# Altium schematic sheet User_Input.SchDoc("User Input")
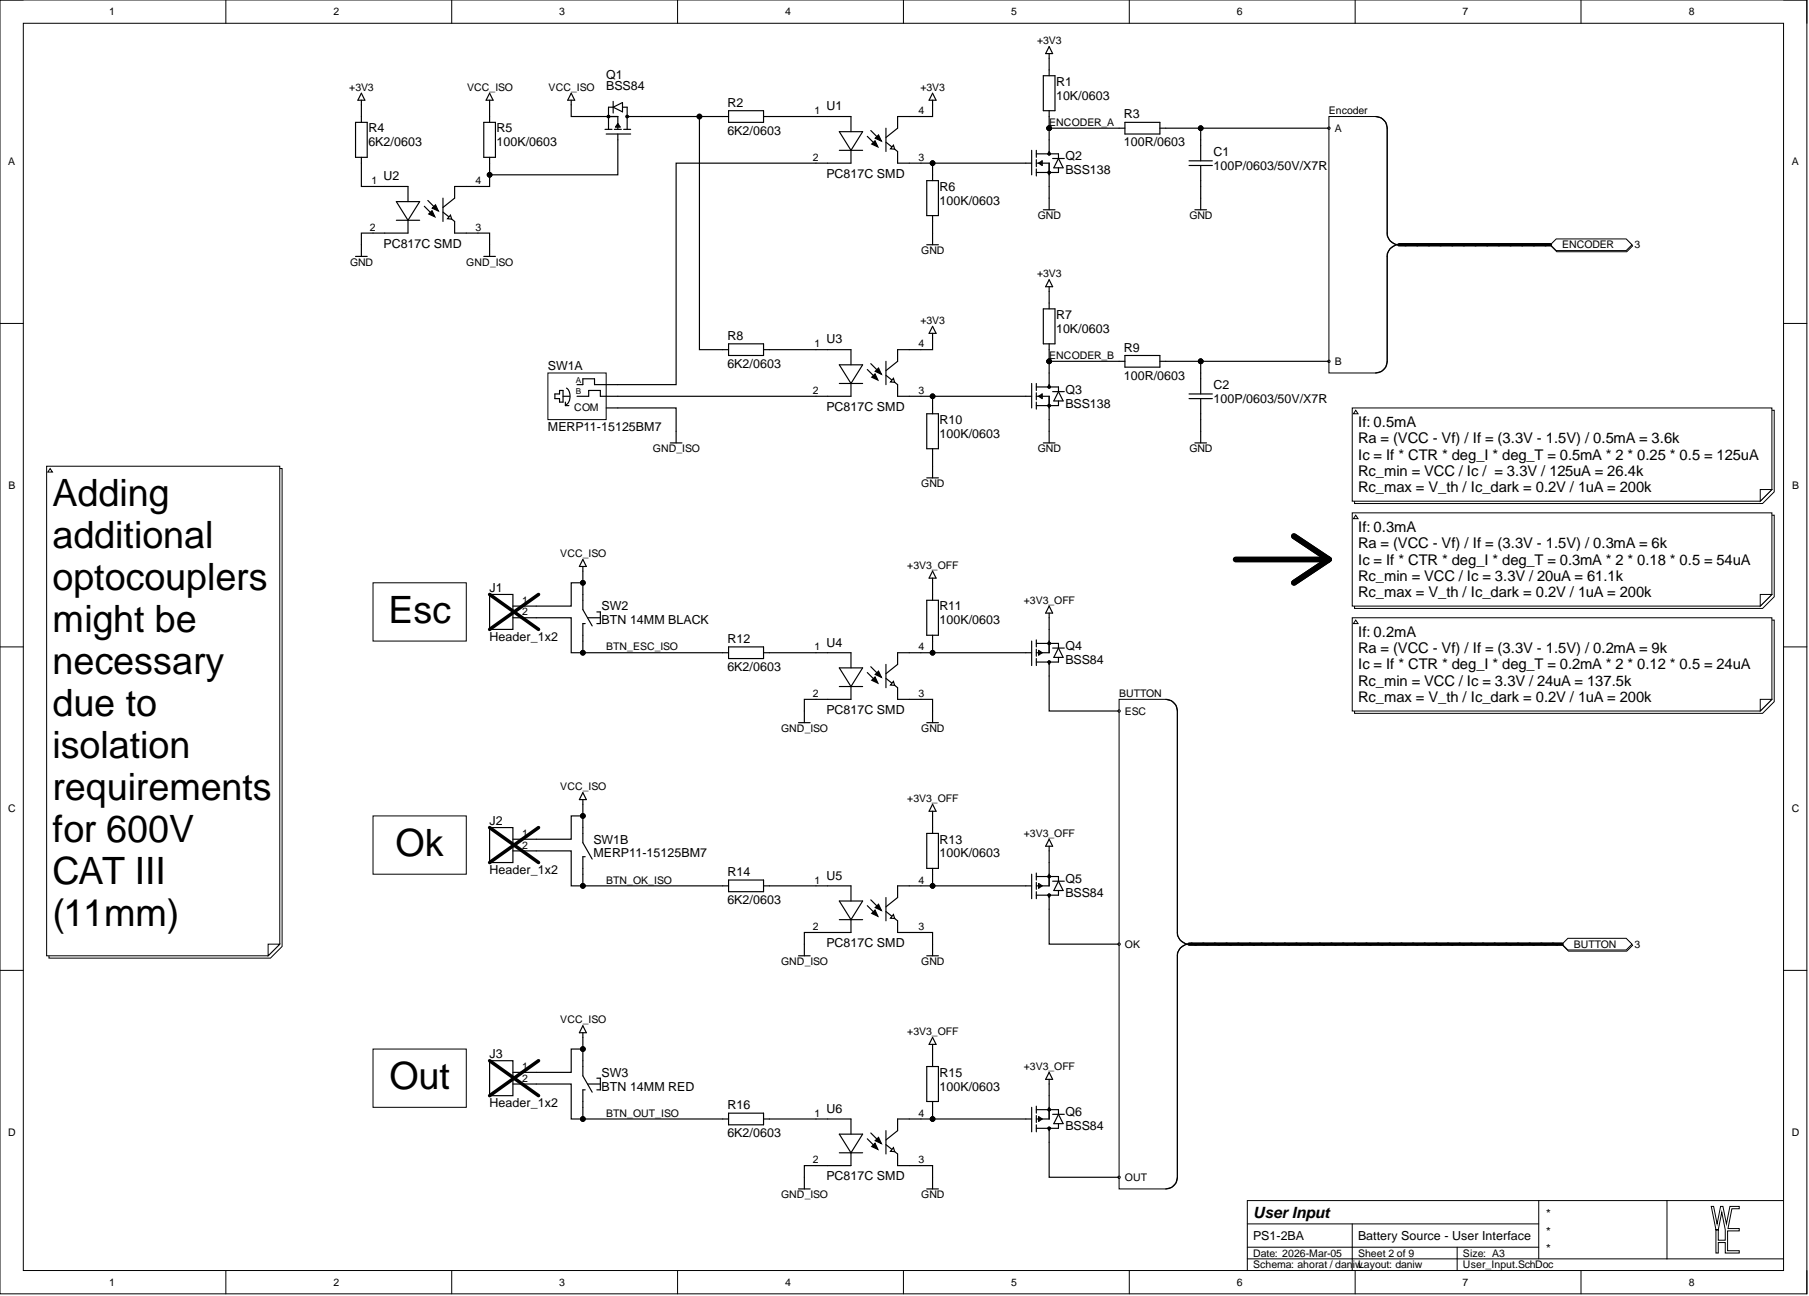
[diagram p0: User_Input.SchDoc]

## Parts
C1  100P/0603/50V/X7R  [CAP0603_X7R]
C2  100P/0603/50V/X7R  [CAP0603_X7R]
J1  Header_1x2  [HEAD_254_1X2]
J2  Header_1x2  [HEAD_254_1X2]
J3  Header_1x2  [HEAD_254_1X2]
Q1  BSS84  [SOT2395P280X125-3-SE]
Q2  BSS138  [SOT2395P280X125-3-SE]
Q3  BSS138  [SOT2395P280X125-3-SE]
Q4  BSS84  [SOT2395P280X125-3-SE]
Q5  BSS84  [SOT2395P280X125-3-SE]
Q6  BSS84  [SOT2395P280X125-3-SE]
R1  10K/0603  [R0603-0.40-WW]
R2  6K2/0603  [R0603-0.40-WW]
R3  100R/0603  [R0603-0.40-WW]
R4  6K2/0603  [R0603-0.40-WW]
R5  100K/0603  [R0603-0.40-WW]
R6  100K/0603  [R0603-0.40-WW]
R7  10K/0603  [R0603-0.40-WW]
R8  6K2/0603  [R0603-0.40-WW]
R9  100R/0603  [R0603-0.40-WW]
R10  100K/0603  [R0603-0.40-WW]
R11  100K/0603  [R0603-0.40-WW]
R12  6K2/0603  [R0603-0.40-WW]
R13  100K/0603  [R0603-0.40-WW]
R14  6K2/0603  [R0603-0.40-WW]
R15  100K/0603  [R0603-0.40-WW]
R16  6K2/0603  [R0603-0.40-WW]
SW1  MERP11-15125BM7  [MERP11-15125BM7]  (parts A,B)
SW2  BTN 14MM BLACK  [RS-BUTTON-14MM-BLACK]
SW3  BTN 14MM RED  [RS-BUTTON-14MM-RED]
U1  PC817C SMD  [SOP254P1020X410-4]
U2  PC817C SMD  [SOP254P1020X410-4]
U3  PC817C SMD  [SOP254P1020X410-4]
U4  PC817C SMD  [SOP254P1020X410-4]
U5  PC817C SMD  [SOP254P1020X410-4]
U6  PC817C SMD  [SOP254P1020X410-4]

## Ports
BUTTON, BUTTON.ESC, BUTTON.OK, BUTTON.OUT, ENCODER, ENCODER.A, ENCODER.B

## Nets
+3V3: R1.1 R4.1 R7.1 U1.4 U3.4
+3V3_OFF: Q4.2 Q5.2 Q6.2 R11.1 R13.1 R15.1
GND: C1.2 C2.2 Q2.2 Q3.2 R6.2 R10.2 U2.2 U4.3 U5.3 U6.3
GND_ISO: SW1.C(COM) U2.3 U4.2 U5.2 U6.2
VCC_ISO: J1.1 J2.1 J3.1 Q1.2 R5.1 SW1.1 SW2.1 SW3.1
BTN_ESC_ISO: J1.2 R12.1 SW2.2
BTN_OK_ISO: J2.2 R14.1 SW1.2
BTN_OUT_ISO: J3.2 R16.1 SW3.2
ENCODER_A: Q2.3 R1.2 R3.1
ENCODER_B: Q3.3 R7.2 R9.1
NetC1_1: C1.1 R3.2 ; port ENCODER
NetC2_1: C2.1 R9.2 ; port ENCODER
NetQ1_1: Q1.1 R5.2 U2.4
NetQ1_3: Q1.3 R2.1 R8.1
NetQ2_1: Q2.1 R6.1 U1.3
NetQ3_1: Q3.1 R10.1 U3.3
NetQ4_1: Q4.1 R11.2 U4.4
NetQ4_3: Q4.3 ; port BUTTON
NetQ5_1: Q5.1 R13.2 U5.4
NetQ5_3: Q5.3 ; port BUTTON
NetQ6_1: Q6.1 R15.2 U6.4
NetQ6_3: Q6.3 ; port BUTTON
NetR2_2: R2.2 U1.1
NetR4_2: R4.2 U2.1
NetR8_2: R8.2 U3.1
NetR12_2: R12.2 U4.1
NetR14_2: R14.2 U5.1
NetR16_2: R16.2 U6.1
NetSW1_A: SW1.A U1.2
NetSW1_B: SW1.B U3.2

## Library data of the parts
C1 C2 = 100P/0603/50V/X7R: CAP SM 0603 100pF 50V X7R; Manufacturer: GENERIC; Manufacturer 1: YAGEO; Manufacturer Part Number 1: CC0603KPX7R9BB101; Absolute Value: 1E-10; Database Table Name: C$; Eurocircuits GPN: GPC0603101; Component Kind: Standard; HelpURL: https://www.yageo.com/upload/media/product/app/datasheet/mlcc/upy-gphc_x7r_6_3v-to-250v.pdf; Manufacturer 2: YAGEO; Manufacturer Part Number 2: CC0603KRX7R9BB101; Package: 0603; Part Number: 100P/0603/50V/X7R; Supplier 1: Digi-Key; Supplier 2: Digi-Key; Supplier Part Number 1: 13-CC0603KPX7R9BB101CT-ND; Supplier Part Number 2: 311-3601-1-ND; Value: 100pF; Library Name: daniw_altium_lib.DbLib
J1-J3 = Header_1x2: Header 100 mil 1x2; Manufacturer: GENERIC; Database Table Name: CON$; System: Connector; Component Kind: Standard; Part Number: Header_1x2; Library Name: daniw_altium_lib.DbLib
Q1 Q4-Q6 = BSS84: 50V P-Channel MOSFET; Manufacturer: GENERIC; Manufacturer 1: Good-Ark Semiconductor; Database Table Name: T$; Component Kind: Standard; HelpURL: https://goodarksemi.com/docs/datasheets/mosfets/BSS84.pdf; JLC Type: Extended; Manufacturer 2: LRC; Manufacturer 3: Jiangsu Changjing Electronics Technology Co., Ltd.; Manufacturer Part Number 1: BSS84; Manufacturer Part Number 2: LBSS84LT1G; Manufacturer Part Number 3: BSS84; Package: SOT23; Part Number: BSS84; Supplier 1: Digi-Key; Supplier 2: LCSC; Supplier 3: LCSC; Supplier Part Number 1: 4786-BSS84CT-ND; Supplier Part Number 2: C8492; Supplier Part Number 3: C114481; Library Name: daniw_altium_lib.DbLib
Q2 Q3 = BSS138: N-Kanal 50 V 220mA (Ta) 350mW (Ta) Oberflächenmontage SOT-23; Manufacturer: GENERIC; Manufacturer 1: ANBON SEMICONDUCTOR (INT'L) LIMITED; Database Table Name: T$; Component Kind: Standard; HelpURL: https://mm.digikey.com/Volume0/opasdata/d220001/medias/docus/3037/BSS138.pdf; JLC Type: Extended; Manufacturer 2: FOSAN; Manufacturer 3: FOSAN; Manufacturer Part Number 1: BSS138; Manufacturer Part Number 2: BSS138; Manufacturer Part Number 3: BSS138; Package: SOT23; Part Number: BSS138; Supplier 1: Digi-Key; Supplier 2: LCSC; Supplier 3: LCSC; Supplier Part Number 1: 4530-BSS138CT-ND; Supplier Part Number 2: C2926186; Supplier Part Number 3: C2926186; Library Name: daniw_altium_lib.DbLib
R1 R7 = 10K/0603: RES SM 0603 10K; Manufacturer: GENERIC; Manufacturer 1: YAGEO; Manufacturer Part Number 1: RC0603FR-1310KL; Absolute Value: 10000; Database Table Name: R$; Eurocircuits GPN: GPR060310K; Component Kind: Standard; HelpURL: https://www.yageogroup.com/content/datasheet/asset/file/PYU-RC_GROUP_51_ROHS_L; JLC Type: Basic; Manufacturer 2: YAGEO; Manufacturer 3: UNI-ROYAL(Uniroyal Elec); Manufacturer Part Number 2: RC0603FR-1010KL; Manufacturer Part Number 3: 0603WAF1002T5E; Package: 0603; Part Number: 10K/0603; Supplier 1: Digi-Key; Supplier 2: Digi-Key; Supplier 3: LCSC; Supplier Part Number 1: 13-RC0603FR-1310KLCT-ND; Supplier Part Number 2: 13-RC0603FR-1010KLCT-ND; Supplier Part Number 3: C25804; Value: 10K; Library Name: daniw_altium_lib.DbLib
R2 R4 R8 R12 R14 R16 = 6K2/0603: RES SM 0603 6K2; Manufacturer: GENERIC; Manufacturer 1: YAGEO; Manufacturer Part Number 1: RC0603FR-136K2L; Absolute Value: 6200; Database Table Name: R$; Eurocircuits GPN: GPR06036K2; Component Kind: Standard; HelpURL: https://www.yageogroup.com/content/datasheet/asset/file/PYU-RC_GROUP_51_ROHS_L; JLC Type: Basic; Manufacturer 2: YAGEO; Manufacturer 3: UNI-ROYAL; Manufacturer Part Number 2: RC0603FR-106K2L; Manufacturer Part Number 3: 0603WAF6201T5E; Package: 0603; Part Number: 6K2/0603; Supplier 1: Digi-Key; Supplier 2: Digi-Key; Supplier 3: LCSC; Supplier Part Number 1: 13-RC0603FR-136K2LCT-ND; Supplier Part Number 2: 13-RC0603FR-106K2LCT-ND; Supplier Part Number 3: C4260; Value: 6K2; Library Name: daniw_altium_lib.DbLib
R3 R9 = 100R/0603: RES SM 0603 100R; Manufacturer: GENERIC; Manufacturer 1: YAGEO; Manufacturer Part Number 1: RC0603FR-13100RL; Absolute Value: 100; Database Table Name: R$; Eurocircuits GPN: GPR0603100R; Component Kind: Standard; HelpURL: https://www.yageogroup.com/content/datasheet/asset/file/PYU-RC_GROUP_51_ROHS_L; Manufacturer 2: YAGEO; Manufacturer 3: UNI-ROYAL(Uniroyal Elec); Manufacturer Part Number 2: RC0603FR-10100RL; Manufacturer Part Number 3: 0603WAF1000T5E; Package: 0603; Part Number: 100R/0603; Supplier 1: Digi-Key; Supplier 2: Digi-Key; Supplier 3: LCSC; Supplier Part Number 1: 13-RC0603FR-13100RLCT-ND; Supplier Part Number 2: 13-RC0603FR-10100RLCT-ND; Supplier Part Number 3: C22775; Value: 100R; Library Name: daniw_altium_lib.DbLib
R5 R6 R10 R11 R13 R15 = 100K/0603: RES SM 0603 100K; Manufacturer: GENERIC; Manufacturer 1: YAGEO; Manufacturer Part Number 1: RC0603FR-13100KL; Absolute Value: 100000; Database Table Name: R$; Eurocircuits GPN: GPR0603100K; Component Kind: Standard; HelpURL: https://www.yageogroup.com/content/datasheet/asset/file/PYU-RC_GROUP_51_ROHS_L; JLC Type: Basic; Manufacturer 2: YAGEO; Manufacturer 3: UNI-ROYAL(Uniroyal Elec); Manufacturer Part Number 2: RC0603FR-10100KL; Manufacturer Part Number 3: 0603WAF1003T5E; Package: 0603; Part Number: 100K/0603; Supplier 1: Digi-Key; Supplier 2: Digi-Key; Supplier 3: LCSC; Supplier Part Number 1: 13-RC0603FR-13100KLCT-ND; Supplier Part Number 2: 13-RC0603FR-10100KLCT-ND; Supplier Part Number 3: C25803; Value: 100K; Library Name: daniw_altium_lib.DbLib
SW1 = MERP11-15125BM7: ENCODER, WITH PUSHBUTTON, 15/30; Manufacturer: Knitter-Switch; Database Table Name: Misc$; Component Kind: Standard; HelpURL: https://knitter-switch.com/wp-content/media/pms/Encoder/MER%2011%20_%20MERS%2011%20_%20MERP%2011%20_%20MERPS%2011/MERP11-15125BM7/MERP11-15125BM7.pdf; Manufacturer 1: Knitter-Switch; Manufacturer Part Number 1: MERP11-15125BM7; Part Number: MERP11-15125BM7; Supplier 1: Digi-Key; Supplier Part Number 1: 3746-MERP11-15125BM7-ND; Library Name: daniw_altium_lib.DbLib
SW2 = BTN 14MM BLACK: Pushbutton switch panel mount 14mm diameter, black; Manufacturer: RS PRO; Manufacturer Part Number 1: 7346704; Database Table Name: Misc$; Component Kind: Standard; HelpURL: https://docs.rs-online.com/1486/A700000007944938.pdf; Manufacturer 1: RS PRO; Manufacturer 2: RS PRO; Manufacturer Part Number 2: 734-6704; Part Number: BTN 14MM BLACK; Supplier 1: Distrelec; Supplier 2: RS-Components; Supplier Part Number 1: 30403423; Supplier Part Number 2: 734-6704; Library Name: daniw_altium_lib.DbLib
SW3 = BTN 14MM RED: Pushbutton switch panel mount 14mm diameter, red; Manufacturer: RS PRO; Manufacturer Part Number 1: 7346716; Database Table Name: Misc$; Component Kind: Standard; HelpURL: https://docs.rs-online.com/8efa/A700000007944946.pdf; Manufacturer 1: RS PRO; Manufacturer 2: RS PRO; Manufacturer Part Number 2: 734-6716; Part Number: BTN 14MM RED; Supplier 1: Distrelec; Supplier 2: RS-Components; Supplier Part Number 1: 30395366; Supplier Part Number 2: 734-6716; Library Name: daniw_altium_lib.DbLib
U1-U6 = PC817C SMD: Optocoupler; Manufacturer: Diodes Incorporated; Manufacturer Part Number 1: DPC817S-C-TR; Database Table Name: Integrated$; Component Kind: Standard; HelpURL: https://www.diodes.com/assets/Datasheets/DPC817-Series.pdf; JLC Type: Extended; Manufacturer 1: Diodes Incorporated; Manufacturer 3: UMW; Manufacturer Part Number 3: PC817C-S; Package: SOP-4; Part Number: PC817C SMD; Supplier 1: Digi-Key; Supplier 3: LCSC; Supplier Part Number 1: 31-DPC817S-C-TRCT-ND; Supplier Part Number 3: C3008369; Library Name: daniw_altium_lib.DbLib
